# Altium schematic sheet pca20018 - Keyboard.SchDoc
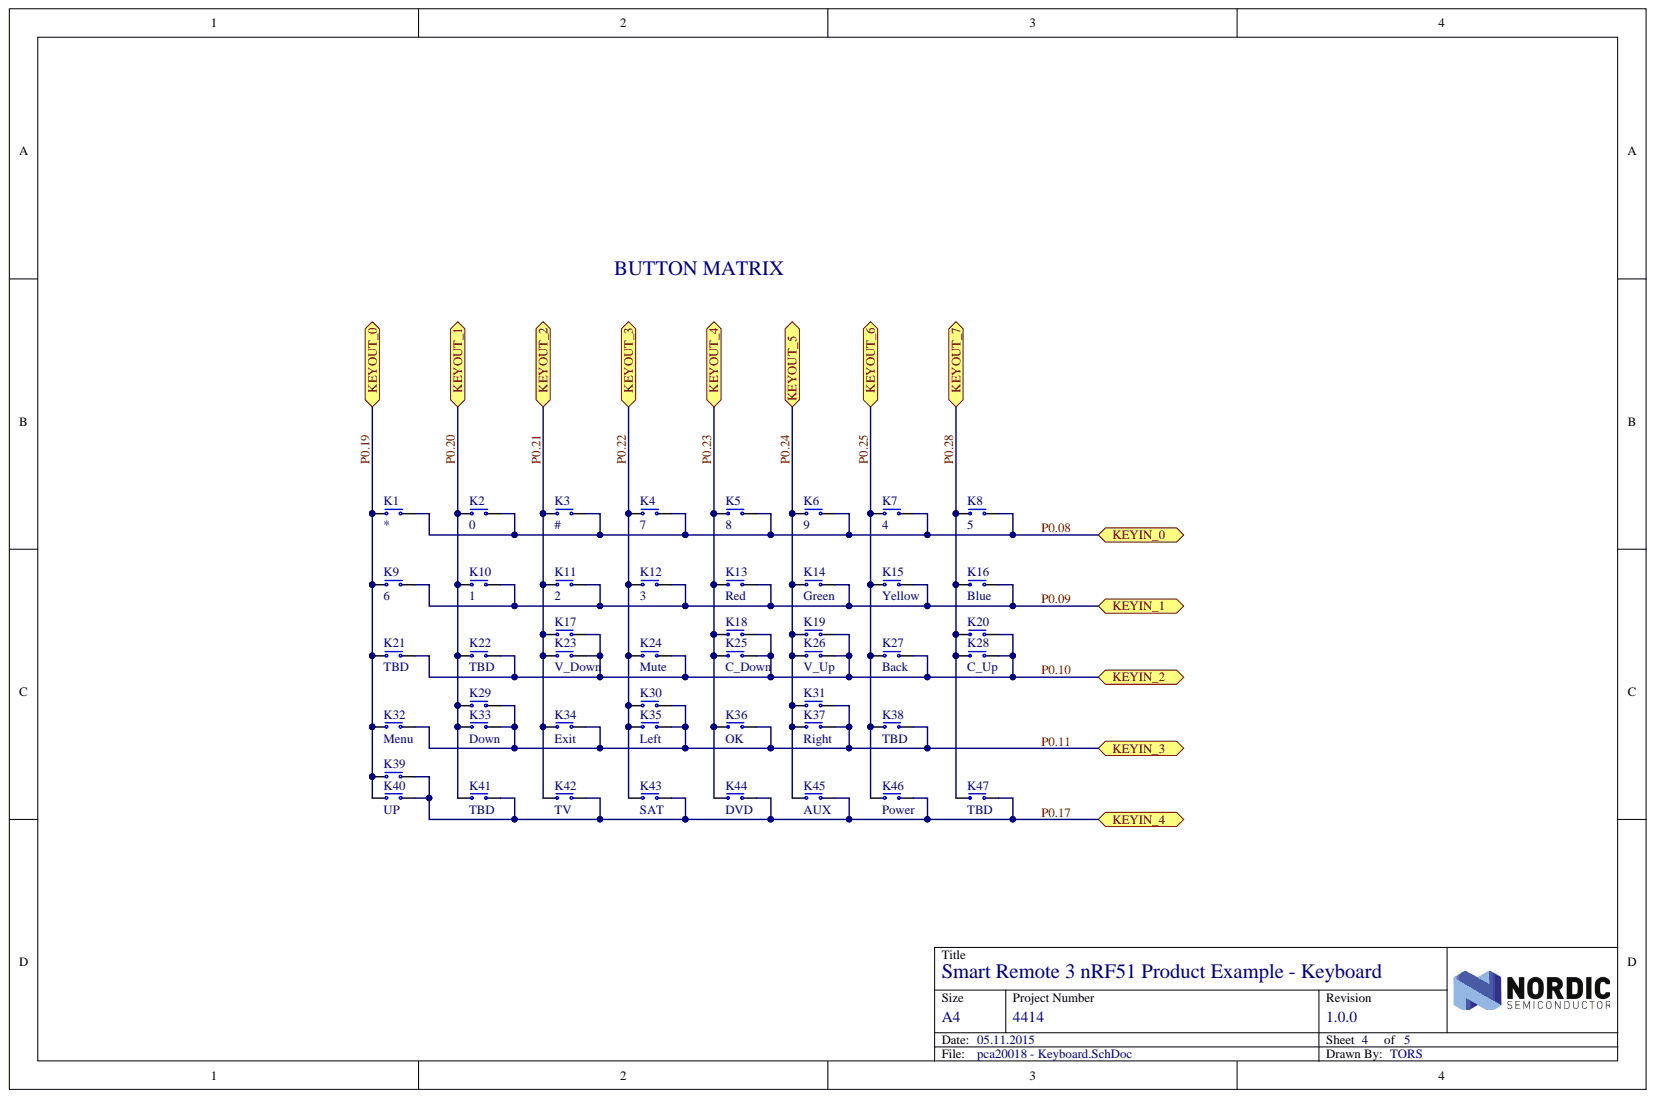
[diagram p0: pca20018 - Keyboard.SchDoc]

## Parts
K1  (no value)  [PCB Switch]  (no BOM)
K2  0  [PCB Switch]  (no BOM)
K3  #  [PCB Switch]  (no BOM)
K4  7  [PCB Switch]  (no BOM)
K5  8  [PCB Switch]  (no BOM)
K6  9  [PCB Switch]  (no BOM)
K7  4  [PCB Switch]  (no BOM)
K8  5  [PCB Switch]  (no BOM)
K9  6  [PCB Switch]  (no BOM)
K10  1  [PCB Switch]  (no BOM)
K11  2  [PCB Switch]  (no BOM)
K12  3  [PCB Switch]  (no BOM)
K13  Red  [PCB Switch]  (no BOM)
K14  Green  [PCB Switch]  (no BOM)
K15  Yellow  [PCB Switch]  (no BOM)
K16  Blue  [PCB Switch]  (no BOM)
K17  PCB SW  [PCB Switch]  (value not drawn)  (no BOM)
K18  PCB SW  [PCB Switch]  (value not drawn)  (no BOM)
K19  PCB SW  [PCB Switch]  (value not drawn)  (no BOM)
K20  PCB SW  [PCB Switch]  (value not drawn)  (no BOM)
K21  TBD  [PCB Switch]  (no BOM)
K22  TBD  [PCB Switch]  (no BOM)
K23  V_Down  [PCB Switch]  (no BOM)
K24  Mute  [PCB Switch]  (no BOM)
K25  C_Down  [PCB Switch]  (no BOM)
K26  V_Up  [PCB Switch]  (no BOM)
K27  Back  [PCB Switch]  (no BOM)
K28  C_Up  [PCB Switch]  (no BOM)
K29  PCB SW  [PCB Switch]  (value not drawn)  (no BOM)
K30  PCB SW  [PCB Switch]  (value not drawn)  (no BOM)
K31  PCB SW  [PCB Switch]  (value not drawn)  (no BOM)
K32  Menu  [PCB Switch]  (no BOM)
K33  Down  [PCB Switch]  (no BOM)
K34  Exit  [PCB Switch]  (no BOM)
K35  Left  [PCB Switch]  (no BOM)
K36  OK  [PCB Switch]  (no BOM)
K37  Right  [PCB Switch]  (no BOM)
K38  TBD  [PCB Switch]  (no BOM)
K39  PCB SW  [PCB Switch]  (value not drawn)  (no BOM)
K40  UP  [PCB Switch]  (no BOM)
K41  TBD  [PCB Switch]  (no BOM)
K42  TV  [PCB Switch]  (no BOM)
K43  SAT  [PCB Switch]  (no BOM)
K44  DVD  [PCB Switch]  (no BOM)
K45  AUX  [PCB Switch]  (no BOM)
K46  Power  [PCB Switch]  (no BOM)
K47  TBD  [PCB Switch]  (no BOM)

## Ports
KEYIN_0, KEYIN_1, KEYIN_2, KEYIN_3, KEYIN_4, KEYOUT_0, KEYOUT_1, KEYOUT_2, KEYOUT_3, KEYOUT_4, KEYOUT_5, KEYOUT_6, KEYOUT_7

## Nets
P0.08: K1.1 K2.1 K3.1 K4.1 K5.1 K6.1 K7.1 K8.1 ; port KEYIN_0
P0.09: K9.1 K10.1 K11.1 K12.1 K13.1 K14.1 K15.1 K16.1 ; port KEYIN_1
P0.10: K17.1 K18.1 K19.1 K20.1 K21.1 K22.1 K23.1 K24.1 K25.1 K26.1 K27.1 K28.1 ; port KEYIN_2
P0.11: K29.1 K30.1 K31.1 K32.1 K33.1 K34.1 K35.1 K36.1 K37.1 K38.1 ; port KEYIN_3
P0.17: K39.1 K40.1 K41.1 K42.1 K43.1 K44.1 K45.1 K46.1 K47.1 ; port KEYIN_4
P0.19: K1.2 K9.2 K21.2 K32.2 K39.2 K40.2 ; port KEYOUT_0
P0.20: K2.2 K10.2 K22.2 K29.2 K33.2 K41.2 ; port KEYOUT_1
P0.21: K3.2 K11.2 K17.2 K23.2 K34.2 K42.2 ; port KEYOUT_2
P0.22: K4.2 K12.2 K24.2 K30.2 K35.2 K43.2 ; port KEYOUT_3
P0.23: K5.2 K13.2 K18.2 K25.2 K36.2 K44.2 ; port KEYOUT_4
P0.24: K6.2 K14.2 K19.2 K26.2 K31.2 K37.2 K45.2 ; port KEYOUT_5
P0.25: K7.2 K15.2 K27.2 K38.2 K46.2 ; port KEYOUT_6
P0.28: K8.2 K16.2 K20.2 K28.2 K47.2 ; port KEYOUT_7

## Library data of the parts
K1-K16 K21-K28 K32-K38 K40-K47 = KEY_SWITCH_SR3: Keyboard matrix switch; Artikkelnr Noca: N.A.; Database Table Name: Rele_Switch$; Value: PCB SW; Artikkelnr Simpro: N.A.; LatestRevisionDate: 2015-05-05; Library Name: Nordic Discrete DB.DbLib; Part Number: KEY_SWITCH_SR3; Pin Count: 2; Published: 2015-05-05; Publisher: Nordic Semiconductor
K17-K20 K29-K31 K39 = KEY_SWITCH_SR3: Keyboard matrix switch; Artikkelnr Noca: N.A.; Database Table Name: Rele_Switch$; Artikkelnr Simpro: N.A.; LatestRevisionDate: 2015-05-05; Library Name: Nordic Discrete DB.DbLib; Part Number: KEY_SWITCH_SR3; Pin Count: 2; Published: 2015-05-05; Value: PCB SW; Publisher: Nordic Semiconductor
